# Altium schematic sheet Main.SchDoc(Main)
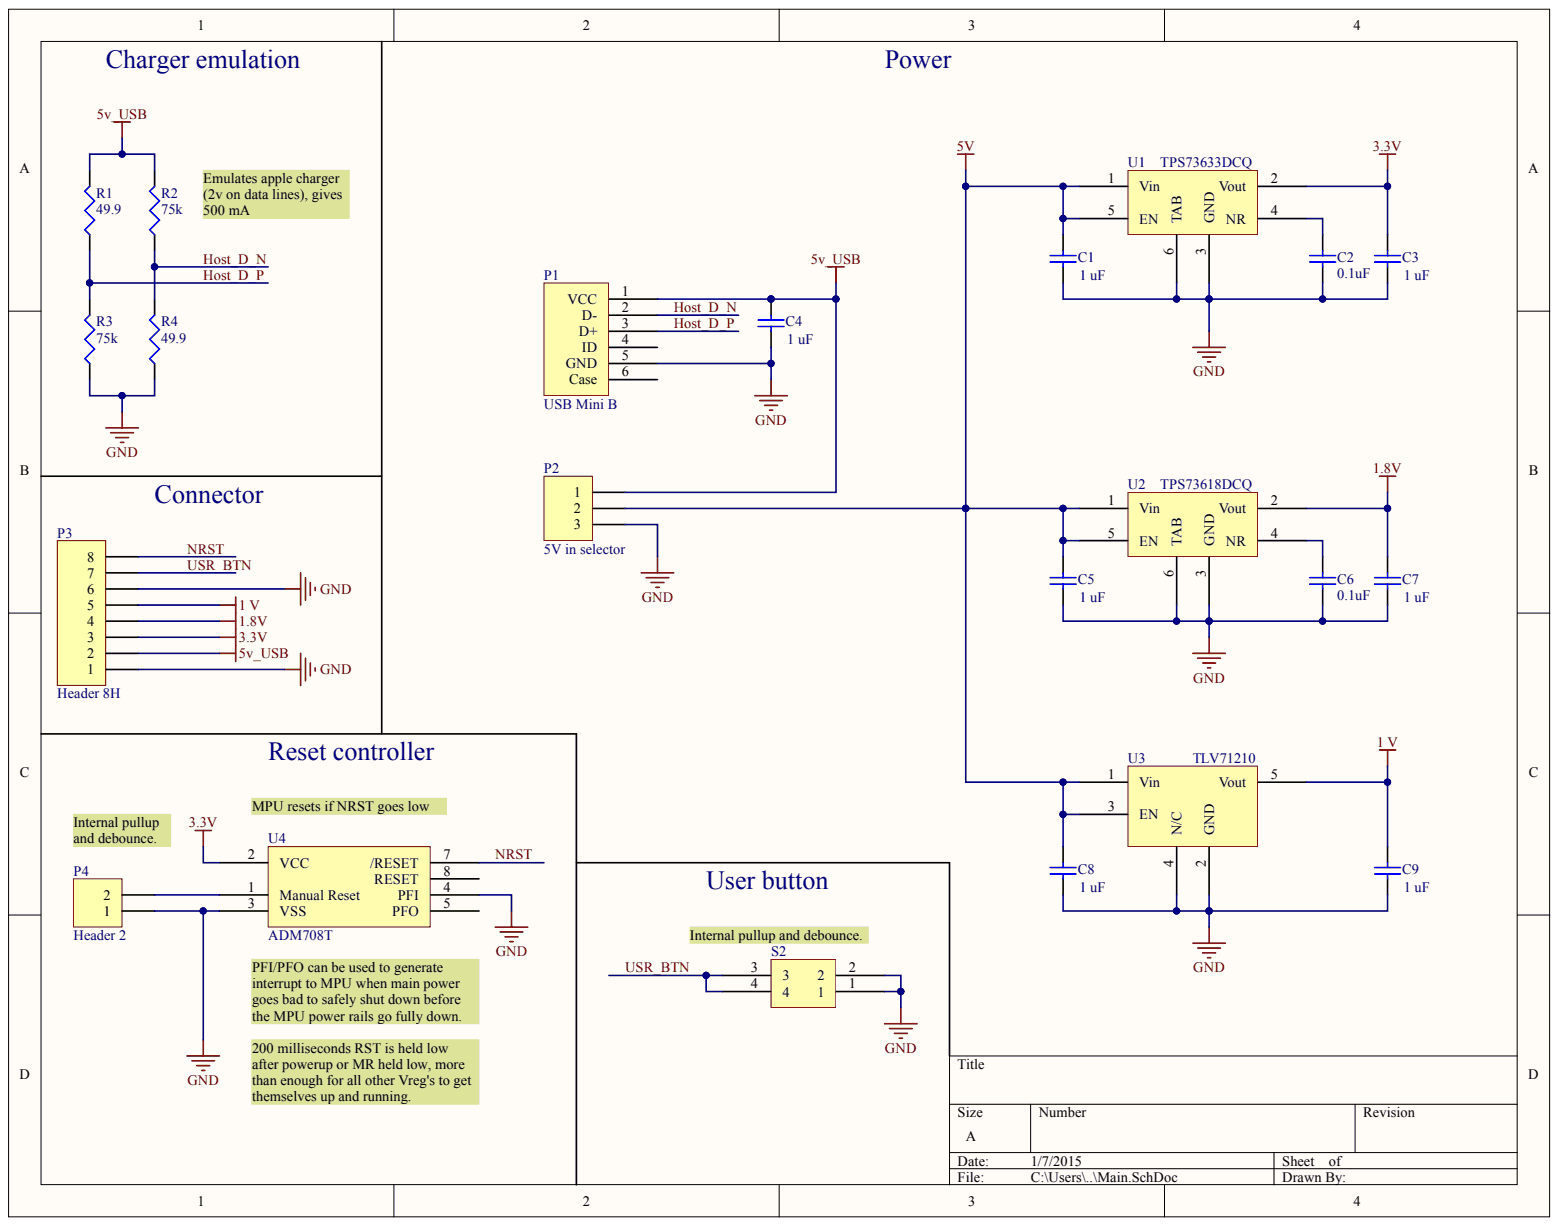
[diagram p0: Main.SchDoc]

## Parts
C1  value 1 uF  [0402]  (hidden comment: CL05A105KQ5NNNC)
C2  value 0.1uF  [0402]  (hidden comment: CL05B104KQ5NNNC)
C3  value 1 uF  [0402]  (hidden comment: CL05A105KQ5NNNC)
C4  value 1 uF  [0402]  (hidden comment: CL05A105KQ5NNNC)
C5  value 1 uF  [0402]  (hidden comment: CL05A105KQ5NNNC)
C6  value 0.1uF  [0402]  (hidden comment: CL05B104KQ5NNNC)
C7  value 1 uF  [0402]  (hidden comment: CL05A105KQ5NNNC)
C8  value 1 uF  [0402]  (hidden comment: CL05A105KQ5NNNC)
C9  value 1 uF  [0402]  (hidden comment: CL05A105KQ5NNNC)
P1  USB Mini B  [USB Mini B]
P2  5V in selector  [HDR1X3]
P3  Header 8H  [Header_8_Right]
P4  Header 2  [HDR1X2]
R1  value 49.9  [J1-0603]  (hidden comment: MCR03ERTF4992)
R2  value 75k  [J1-0603]  (hidden comment: MCR03ERTF7502)
R3  value 75k  [J1-0603]  (hidden comment: MCR03ERTF7502)
R4  value 49.9  [J1-0603]  (hidden comment: MCR03ERTF4992)
S2  1825910-2  [Tactile_Switch_DIP]  (value not drawn)
U1  TPS73633DCQ  [SOT127P706X180-6N]
U2  TPS73618DCQ  [SOT127P706X180-6N]
U3  TLV71210  [SOT95P280X145-5N]
U4  ADM708T  [SOIC127P600X175-8N]

## Nets
1 V: C9.2 P3.5 U3.5(Vout)
1.8V: C7.2 P3.4 U2.2(Vout)
3.3V: C3.2 P3.3 U1.2(Vout) U4.2
5V: C1.2 C5.2 C8.2 P2.2 U1.1(Vin) U1.5(EN) U2.1(Vin) U2.5(EN) U3.1(Vin) U3.3(EN)
5V_USB: C4.2 P1.1(VCC) P2.1 P3.2 R1.2 R2.2
GND: C1.1 C2.1 C3.1 C4.1 C5.1 C6.1 C7.1 C8.1 C9.1 P1.5(GND) P2.3 P3.1 P3.6 P4.1 R3.1 R4.1 S2.1 S2.2 U1.3(GND) U1.6(TAB) U2.3(GND) U2.6(TAB) U3.2(GND) U3.4(N/C) U4.3 U4.4
HOST_D_N: P1.2(D-) R2.1 R4.2
HOST_D_P: P1.3(D+) R1.1 R3.2
NRST: P3.8 U4.7
USR_BTN: P3.7 S2.3 S2.4
NetC2_2: C2.2 U1.4(NR)
NetC6_2: C6.2 U2.4(NR)
NetP4_2: P4.2 U4.1

Not connected: P1 4(ID) 6(Case); U4 5 8

## Library data of the parts
C1 C3-C5 C7-C9 = CL05A105KQ5NNNC: Cap Ceramic 1uF 6.3V X5R 10% SMD 0402; Component Kind: Standard; LatestRevisionDate: 29-May-2009; LatestRevisionNote: IPC-7351 Footprint Added.; PackageDescription: Chip Capacitor; PackageReference: C1206; Published: 8-Jun-2000; Signal Integrity: Cap Semi; Simulation: CAP; Supplier 1: Digi-Key; Supplier 2: Arrow; Supplier Part Number 1: 1276-1010-1-ND; Supplier Part Number 2: _TToi4WPdvcZSNXTq9nwNqdJ2ov5c8o4dzi8yEgOGKwZVywxIBNyoAQstvnyfZZ0; Library Name: Linux_Board_SCHEM_LIB.SchLib; Publisher: Altium Limited
C2 C6 = CL05B104KQ5NNNC: Cap Ceramic 0.1uF 6.3V X7R 10% SMD 0402; Component Kind: Standard; LatestRevisionDate: 29-May-2009; LatestRevisionNote: IPC-7351 Footprint Added.; PackageDescription: Chip Capacitor; PackageReference: C1206; Published: 8-Jun-2000; Signal Integrity: Cap Semi; Simulation: CAP; Supplier 1: Arrow; Supplier 2: Digi-Key; Supplier Part Number 1: c0Ft5huTF1PnwzXLA59QPYxRs3E3aghew1LSAii7cAMZVywxIBNyoAQstvnyfZZ0; Supplier Part Number 2: 1276-1511-1-ND; Library Name: Linux_Board_SCHEM_LIB.SchLib; Publisher: Altium Limited
P1 = USB Mini B: USB Mini B connector; Component Kind: Standard; Signal Integrity: Guessed Model; Library Name: Linux_Board_SCHEM_LIB.SchLib
P2 = Header 3: Header, 3-Pin; Component Kind: Standard; LatestRevisionDate: 17-Jul-2002; LatestRevisionNote: Re-released for DXP Platform.; Library Name: Miscellaneous Connectors.IntLib; Signal Integrity: Connector; Publisher: Altium Limited
P3 = Header 8H: Header, 8-Pin, Right Angle_Silkscreen_fixed; Component Kind: Standard; LatestRevisionDate: 17-Jul-2002; LatestRevisionNote: Re-released for DXP Platform.; Signal Integrity: Connector; Library Name: Linux_Board_SCHEM_LIB.SchLib; Publisher: Altium Limited
P4 = Header 2: Header, 2-Pin; Component Kind: Standard; LatestRevisionDate: 17-Jul-2002; LatestRevisionNote: Re-released for DXP Platform.; Library Name: Miscellaneous Connectors.IntLib; Signal Integrity: Connector; Publisher: Altium Limited
R1 R4 = MCR03ERTF4992: RES SMD 49.9K OHM 1% 1/10W 0603; Component Kind: Standard; LatestRevisionDate: 29-May-2009; LatestRevisionNote: IPC-7351 Footprint Added.; PackageDescription: Chip Resistor; PackageReference: J1-0603; Published: 8-Jun-2000; Signal Integrity: Res; Simulation: RESISTOR; Supplier 1: Digi-Key; Supplier Part Number 1: RHM49.9KCFCT-ND; Library Name: Linux_Board_SCHEM_LIB.SchLib; Publisher: Altium Limited
R2 R3 = MCR03ERTF7502: RES SMD 75K OHM 1% 1/10W 0603; Component Kind: Standard; LatestRevisionDate: 29-May-2009; LatestRevisionNote: IPC-7351 Footprint Added.; PackageDescription: Chip Resistor; PackageReference: J1-0603; Published: 8-Jun-2000; Signal Integrity: Res; Simulation: RESISTOR; Supplier 1: Digi-Key; Supplier Part Number 1: RHM75KCFCT-ND; Library Name: Linux_Board_SCHEM_LIB.SchLib; Publisher: Altium Limited
S2 = 1825910-2: Switch Tactile OFF (ON) SPST Round Button PC Pins 0.05A 24VDC 1.56N Thru-Hole; Component Kind: Standard; Signal Integrity: Guessed Model; Supplier 1: Digi-Key; Supplier 2: Arrow; Supplier Part Number 1: 450-1649-ND; Supplier Part Number 2: 6NSco5Hwbz3-OeC5YGdIeRyereGg4-VEbkW-dekFm3o; Library Name: Linux_Board_SCHEM_LIB.SchLib
U1 = TPS73633DCQ: LDO Regulator Pos 3.3V 0.4A 6-Pin(5+Tab) SOT-223; Component Kind: Standard; Signal Integrity: Guessed Model; Supplier 1: Arrow; Supplier 2: Digi-Key; Supplier 3: Digi-Key; Supplier Part Number 1: x4kqLzhUs3t7_ToPcFIr96VdpsS-JqF9bTV_dDXWgdk; Supplier Part Number 2: 296-27065-5-ND; Supplier Part Number 3: 296-16914-1-ND; Library Name: Linux_Board_SCHEM_LIB.SchLib
U2 = TPS73618DCQ: LDO Regulator Pos 1.8V 0.4A 6-Pin(5+Tab) SOT-223; Component Kind: Standard; Signal Integrity: Guessed Model; Supplier 1: Arrow; Supplier 2: Digi-Key; Supplier Part Number 1: Ay2t_YB7Zd6BLrRVbACcY1YiSj98E9fDli3BwOaRxsI; Supplier Part Number 2: 296-34649-5-ND; Library Name: Linux_Board_SCHEM_LIB.SchLib
U3 = TLV71210: IC REG LDO 1V 0.3A SOT23-5; Component Kind: Standard; Signal Integrity: Guessed Model; Supplier 1: Digi-Key; Supplier Part Number 1: 296-29737-1-ND; Library Name: Linux_Board_SCHEM_LIB.SchLib
U4 = ADM708T: Processor Supervisor 3.08V 3.08V 8-Pin SOIC N; Component Kind: Standard; Signal Integrity: Guessed Model; Supplier 1: Arrow; Supplier 2: Farnell; Supplier 3: Digi-Key; Supplier 4: Digi-Key; Supplier 5: Digi-Key; Supplier 6: Digi-Key; Supplier 7: Digi-Key; Supplier 8: Digi-Key; Supplier 9: Arrow; Supplier 10: Arrow; Supplier 11: Arrow; Supplier 12: RS-Components; Supplier Part Number 1: pi2-849Dtl0aCcQWirPEvA041g7yARSvSddtbGVAq1M; Supplier Part Number 2: 1439107; Supplier Part Number 3: ADM708TARZ-ND; Supplier Part Number 4: ADM708TARZ-REELCT-ND; Supplier Part Number 5: ADM708TARZ-REELDKR-ND; Supplier Part Number 6: ADM708TAR-ND; Supplier Part Number 7: ADM708TANZ-ND; Supplier Part Number 8: ADM708TAN-ND; Supplier Part Number 9: SkmR1ivBRQRb0hDgVdOGWHxJ40GOhfmTcixaJP7ENtI; Supplier Part Number 10: GkJktLZFx3J0OrQcbecICYVuybNisnsgd8wvkYno_8k; Supplier Part Number 11: ROz12eUwDRFR9y27IXTEg_kegk2Mn9HNppjRDuJoErA; Supplier Part Number 12: 759-0424; Library Name: Linux_Board_SCHEM_LIB.SchLib
